# Altium schematic sheet CPU.SchDoc
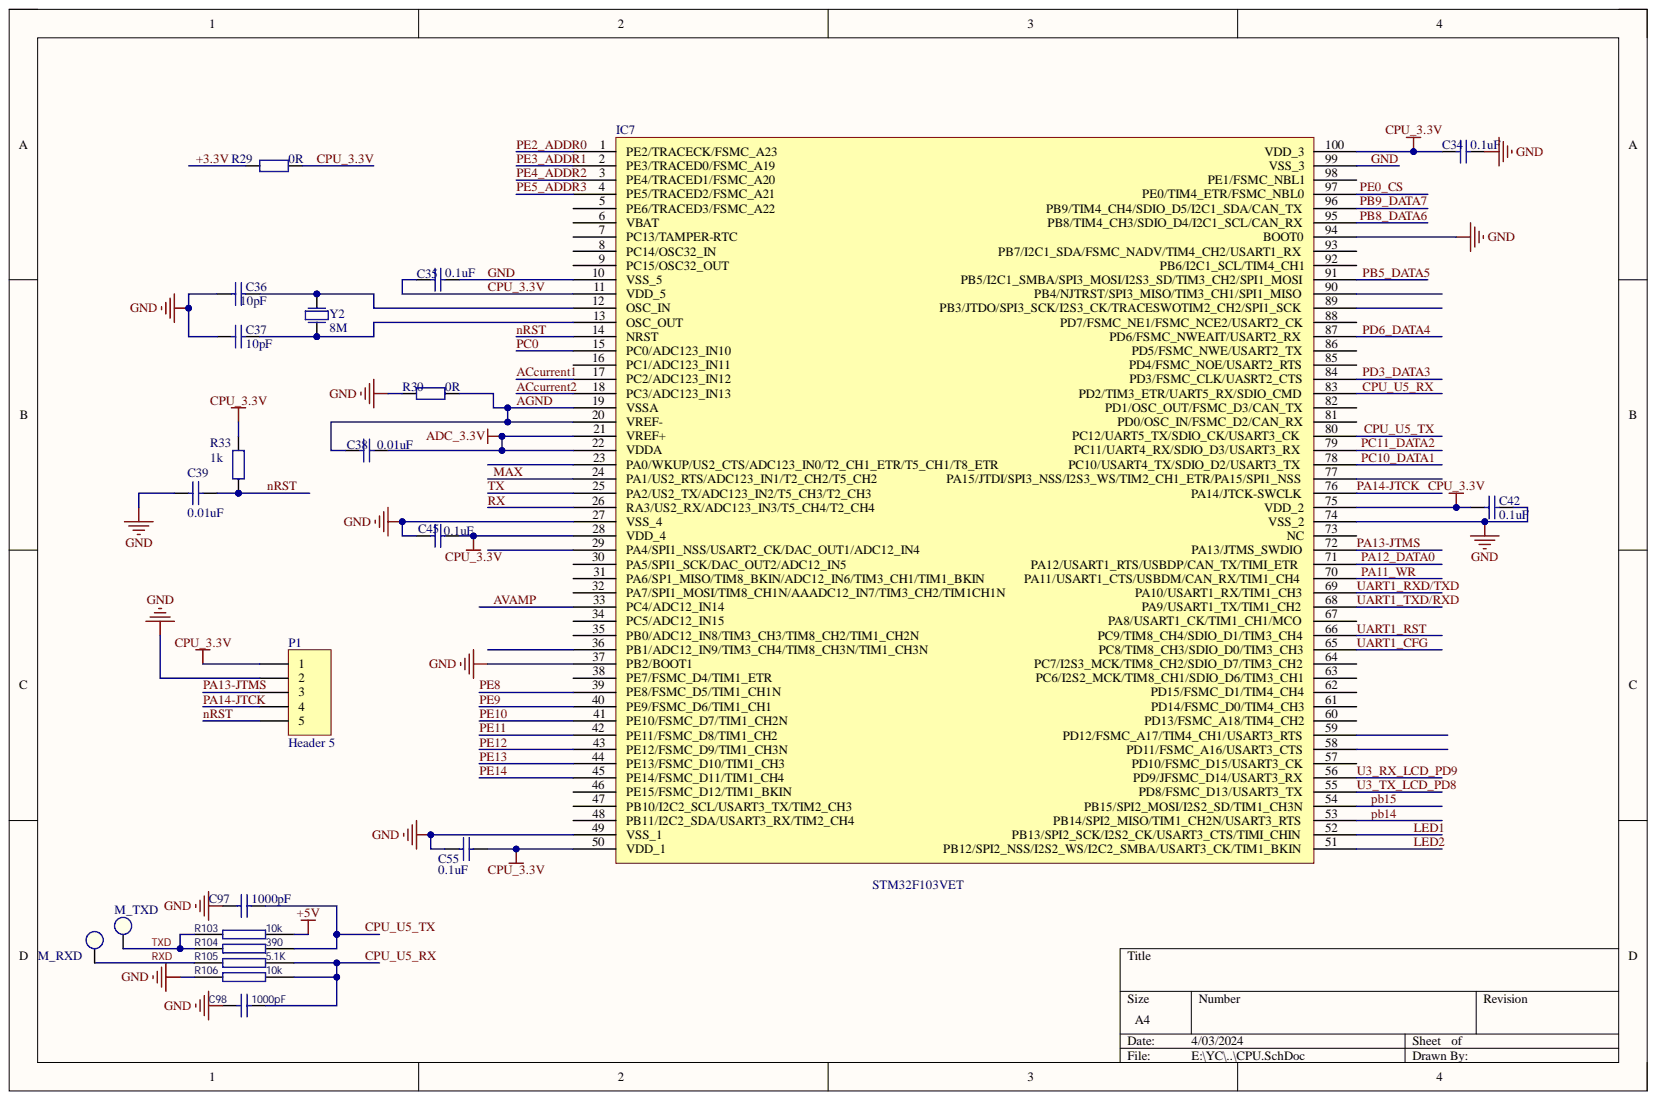
[diagram p0: CPU.SchDoc]

## Parts
C34  0.1uF  [0805C]
C35  0.1uF  [0805C]
C36  value 10pF  [0805C]  (hidden comment: 10P)
C37  value 10pF  [0805C]  (hidden comment: 10P)
C38  0.01uF  [0805C]
C39  0.01uF  [0805C]
C42  0.1uF  [0805C]
C45  0.1uF  [0805C]
C55  0.1uF  [0805C]
C97  1000pF  [0805C]
C98  1000pf  [0805C]
IC7  STM32  [LQFP100]
M_RXD  TERM  [TERM-1]  (value not drawn)
M_TXD  TERM  [TERM-1]  (value not drawn)
P1  Header 5  [HDR1X5_2.54DIP]
R29  0R  [0805]
R30  0R  [0805]
R33  1k  [0805]
R103  10k  [0805]
R104  390  [0805]
R105  5.1K  [0805]
R106  10k  [0805]
Y2  8M  [XTAL3]

## Nets
+5V: R103.1
ADC_3.3V: C38.1 IC7.21(VREF+) IC7.22(VDDA)
+3.3V: R29.2
ACcurrent1: IC7.17(PC2/ADC123_IN12)
ACcurrent2: IC7.18(PC3/ADC123_IN13)
AGND: C38.2 IC7.19(VSSA) IC7.20(VREF-) R30.1
AVAMP: IC7.33(PC4/ADC12_IN14)
CPU_3.3V: C34.2 C35.2 C42.2 C45.2 C55.2 IC7.11(VDD_5) IC7.28(VDD_4) IC7.50(VDD_1) IC7.75(VDD_2) IC7.100(VDD_3) P1.1 R29.1 R33.2
CPU_U5_RX: C98.1 IC7.83(PD2/TIM3_ETR/UART5_RX/SDIO_CMD) R105.2 R106.1
CPU_U5_TX: C97.1 IC7.80(PC12/UART5_TX/SDIO_CK/USART3_CK) R104.1
GND: C34.1 C35.1 C36.1 C37.1 C39.2 C42.1 C45.1 C55.1 C97.2 C98.2 IC7.10(VSS_5) IC7.27(VSS_4) IC7.37(PB2/BOOT1) IC7.49(VSS_1) IC7.74(VSS_2) IC7.94(BOOT0) IC7.99(VSS_3) P1.2 R30.2 R106.2
LED1: IC7.52(PB13/SPI2_SCK/I2S2_CK/USART3_CTS/TIMI_CHIN)
LED2: IC7.51(PB12/SPI2_NSS/I2S2_WS/I2C2_SMBA/USART3_CK/TIM1_BKIN)
MAX: IC7.24(PA1/US2_RTS/ADC123_IN1/T2_CH2/T5_CH2)
nRST: C39.1 IC7.14(NRST) P1.5 R33.1
PA11_WR: IC7.70(PA11/USART1_CTS/USBDM/CAN_RX/TIM1_CH4)
PA12_DATA0: IC7.71(PA12/USART1_RTS/USBDP/CAN_TX/TIMI_ETR)
PA13-JTMS: IC7.72(PA13/JTMS_SWDIO) P1.3
PA14-JTCK: IC7.76(PA14/JTCK-SWCLK) P1.4
PB5_DATA5: IC7.53(PB14/SPI2_MISO/TIM1_CH2N/USART3_RTS) IC7.54(PB15/SPI2_MOSI/I2S2_SD/TIM1_CH3N) IC7.91(PB5/I2C1_SMBA/SPI3_MOSI/I2S3_SD/TIM3_CH2/SPI1_MOSI)  [also labelled pb14, pb15]
PB8_DATA6: IC7.95(PB8/TIM4_CH3/SDIO_D4/I2C1_SCL/CAN_RX)
PB9_DATA7: IC7.96(PB9/TIM4_CH4/SDIO_D5/I2C1_SDA/CAN_TX)
PC0: IC7.15(PC0/ADC123_IN10)
PC10_DATA1: IC7.78(PC10/USART4_TX/SDIO_D2/USART3_TX)
PC11_DATA2: IC7.79(PC11/UART4_RX/SDIO_D3/USART3_RX)
PD3_DATA3: IC7.84(PD3/FSMC_CLK/UASRT2_CTS)
PD6_DATA4: IC7.87(PD6/FSMC_NWEAIT/USART2_RX)
PE0_CS: IC7.97(PE0/TIM4_ETR/FSMC_NBL0)
PE2_ADDR0: IC7.1(PE2/TRACECK/FSMC_A23)
PE3_ADDR1: IC7.2(PE3/TRACED0/FSMC_A19)
PE4_ADDR2: IC7.3(PE4/TRACED1/FSMC_A20)
PE5_ADDR3: IC7.4(PE5/TRACED2/FSMC_A21)
PE8: IC7.39(PE8/FSMC_D5/TIM1_CH1N)
PE9: IC7.40(PE9/FSMC_D6/TIM1_CH1)
PE10: IC7.41(PE10/FSMC_D7/TIM1_CH2N)
PE11: IC7.42(PE11/FSMC_D8/TIM1_CH2)
PE12: IC7.43(PE12/FSMC_D9/TIM1_CH3N)
PE13: IC7.44(PE13/FSMC_D10/TIM1_CH3)
PE14: IC7.45(PE14/FSMC_D11/TIM1_CH4)
RX: IC7.26(RA3/US2_RX/ADC123_IN3/T5_CH4/T2_CH4)
RXD: M_RXD.1 R105.1
TX: IC7.25(PA2/US2_TX/ADC123_IN2/T5_CH3/T2_CH3)
TXD: M_TXD.1 R103.2 R104.2
U3_RX_LCD_PD9: IC7.56(PD9/JFSMC_D14/USART3_RX)
U3_TX_LCD_PD8: IC7.55(PD8/FSMC_D13/USART3_TX)
UART1_CFG: IC7.65(PC8/TIM8_CH3/SDIO_D0/TIM3_CH3)
UART1_RST: IC7.66(PC9/TIM8_CH4/SDIO_D1/TIM3_CH4)
UART1_RXD/TXD: IC7.69(PA10/USART1_RX/TIM1_CH3)
UART1_TXD/RXD: IC7.68(PA9/USART1_TX/TIM1_CH2)
NetC36_2: C36.2 IC7.12(OSC_IN) Y2.1
NetC37_2: C37.2 IC7.13(OSC_OUT) Y2.2

Not connected: IC7 5(PE6/TRACED3/FSMC_A22) 6(VBAT) 7(PC13/TAMPER-RTC) 8(PC14/OSC32_IN) 9(PC15/OSC32_OUT) 16(PC1/ADC123_IN11) 23(PA0/WKUP/US2_CTS/ADC123_IN0/T2_CH1_ETR/T5_CH1/T8_ETR) 29(PA4/SPI1_NSS/USART2_CK/DAC_OUT1/ADC12_IN4) 30(PA5/SPI1_SCK/DAC_OUT2/ADC12_IN5) 31(PA6/SP1_MISO/TIM8_BKIN/ADC12_IN6/TIM3_CH1/TIM1_BKIN) 32(PA7/SPI1_MOSI/TIM8_CH1N/AAADC12_IN7/TIM3_CH2/TIM1CH1N) 34(PC5/ADC12_IN15) 35(PB0/ADC12_IN8/TIM3_CH3/TIM8_CH2/TIM1_CH2N) 36(PB1/ADC12_IN9/TIM3_CH4/TIM8_CH3N/TIM1_CH3N) 38(PE7/FSMC_D4/TIM1_ETR) 46(PE15/FSMC_D12/TIM1_BKIN) 47(PB10/I2C2_SCL/USART3_TX/TIM2_CH3) 48(PB11/I2C2_SDA/USART3_RX/TIM2_CH4) 57(PD10/FSMC_D15/USART3_CK) 58(PD11/FSMC_A16/USART3_CTS) 59(PD12/FSMC_A17/TIM4_CH1/USART3_RTS) 60(PD13/FSMC_A18/TIM4_CH2) 61(PD14/FSMC_D0/TIM4_CH3) 62(PD15/FSMC_D1/TIM4_CH4) 63(PC6/I2S2_MCK/TIM8_CH1/SDIO_D6/TIM3_CH1) 64(PC7/I2S3_MCK/TIM8_CH2/SDIO_D7/TIM3_CH2) 67(PA8/USART1_CK/TIM1_CH1/MCO) 73(NC) 77(PA15/JTDI/SPI3_NSS/I2S3_WS/TIM2_CH1_ETR/PA15/SPI1_NSS) 81(PD0/OSC_IN/FSMC_D2/CAN_RX) 82(PD1/OSC_OUT/FSMC_D3/CAN_TX) 85(PD4/FSMC_NOE/USART2_RTS) 86(PD5/FSMC_NWE/USART2_TX) 88(PD7/FSMC_NE1/FSMC_NCE2/USART2_CK) 89(PB3/JTDO/SPI3_SCK/I2S3_CK/TRACESWOTIM2_CH2/SPI1_SCK) 90(PB4/NJTRST/SPI3_MISO/TIM3_CH1/SPI1_MISO) 92(PB6/I2C1_SCL/TIM4_CH1) 93(PB7/I2C1_SDA/FSMC_NADV/TIM4_CH2/USART1_RX) 98(PE1/FSMC_NBL1)

## Library data of the parts
C34-C39 C42 C45 C55 C97 C98 = Cap: Capacitor; Component Kind: Standard; LatestRevisionDate: 17-Jul-2002; LatestRevisionNote: Re-released for DXP Platform.; PackageReference: RAD-0.3; Published: 8-Jun-2000; Signal Integrity: Cap; Simulation: CAP; Publisher: Altium Limited
  C34 C35 C42 C45 C55: Library Name: lib.SchLib; PackageDescription: Radial Cap, Thru-Hole; 2 Leads; 0.3 in Pin Spacing; Value: 0.1uF
  C36 C37: Library Name: lib.SchLib; PackageDescription: Radial Cap, Thru-Hole; 2 Leads; 0.3 in Pin Spacing
  C38 C39: Library Name: lib.SchLib; PackageDescription: Radial Cap, Thru-Hole; 2 Leads; 0.3 in Pin Spacing; Value: 0.01uF
  C97: Library Name: Miscellaneous Devices.IntLib; PackageDescription: Radial Cap, Thru-Hole; 2 Leads; 0.3 in Pin Spacing; Value: 1000pF
  C98: Library Name: Miscellaneous Devices.IntLib; Value: 1000pF
P1 = Header 5: Header, 5-Pin; Component Kind: Standard; LatestRevisionDate: 17-Jul-2002; LatestRevisionNote: Re-released for DXP Platform.; Library Name: Miscellaneous Connectors.IntLib; Signal Integrity: Connector; Publisher: Altium Limited
R29 = Res2: Resistor; Component Kind: Standard; LatestRevisionDate: 17-Jul-2002; LatestRevisionNote: Re-released for DXP Platform.; Library Name: Miscellaneous Devices.IntLib; PackageDescription: Axial Device, Thru-Hole; 2 Leads; 0.4 in Pin Spacing; PackageReference: AXIAL-0.4; Published: 8-Jun-2000; Signal Integrity: Res; Simulation: RESISTOR; Value: 0R; Publisher: Altium Limited
R30 = Res2_1: Resistor; Component Kind: Standard; LatestRevisionDate: 17-Jul-2002; LatestRevisionNote: Re-released for DXP Platform.; Library Name: lib.SchLib; PackageDescription: Axial Device, Thru-Hole; 2 Leads; 0.4 in Pin Spacing; PackageReference: AXIAL-0.4; Published: 8-Jun-2000; Signal Integrity: Res; Simulation: RESISTOR; Value: 0R; Publisher: Altium Limited
R33 = R0805: Component Kind: Standard; Library Name: QBB.SchLib
R103-R106 = RES2: Component Kind: Standard
Y2 = 12.000: Crystal Oscillator; Component Kind: Standard; Library Name: 677.SchLib
IC7 = STM32: Component Kind: Standard; Library Name: 地面板.SCHLIB
M_RXD M_TXD = TERM: Component Kind: Standard; Library Name: TR_SCHLIB.SchLib
